# Altium schematic sheet lv_branch_24v.SchDoc(lv_branch_24v)
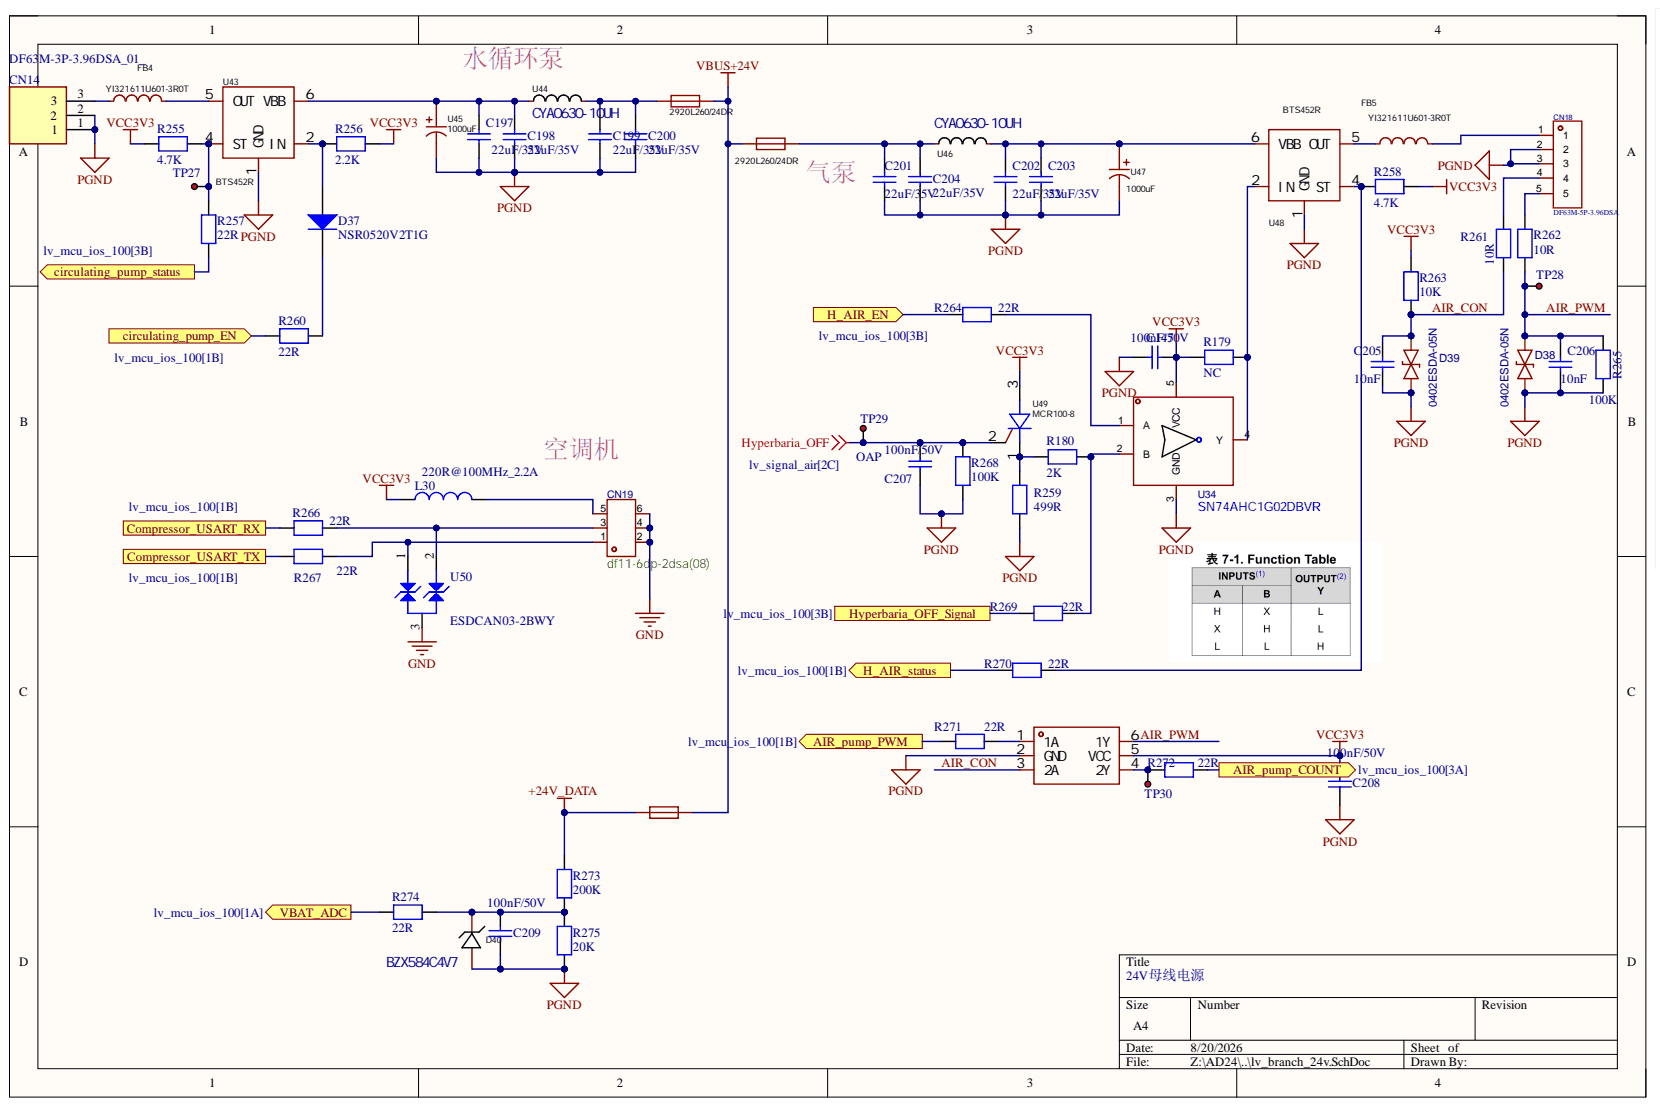
[diagram p0: lv_branch_24v.SchDoc]

## Parts
C147  100nF/50V  [C0402]
C197  22uF/35V  [C1206]
C198  22uF/35V  [C1206]
C199  22uF/35V  [C1206]
C200  22uF/35V  [C1206]
C201  22uF/35V  [C1206]
C202  22uF/35V  [C1206]
C203  22uF/35V  [C1206]
C204  22uF/35V  [C1206]
C205  value 10nF  [C0402]  (hidden comment: 10nF/50V)
C206  value 10nF  [C0402]  (hidden comment: 10nF/50V)
C207  100nF/50V  [C0402]
C208  100nF/50V  [C0402]
C209  100nF/50V  [C0402]
CN14  DF63M-3P-3.96DSA_01_  [DF63M3P396DSA01]
CN18  DF63M-5P-3.96DSA  [CONN-TH_5P-P3.96_HRS_DF63M-5P-3.96DSA]
CN19  df11-6dp-2dsa(08)  [CONN-TH_DF11-6DP-2DSA-01]
D37  NSR0520V2T1G  [SOD-523F_L1.2-W0.8-LS1.7-RD]
D38  0402ESDA-05N  [D0402-BI]
D39  0402ESDA-05N  [D0402-BI]
D40  BZX584C4V7  [SOD-523F_L1.2-W0.8-LS1.7-RD]
F3  2920L260/24DR  [F2920]
F4  2920L260/24DR  [F2920]
F5  2920L260/24DR  [F2920]
FB4  YI321611U601-3R0T  [L1206]
FB5  YI321611U601-3R0T  [L1206]
L30  220R@100MHz_2.2A  [L0603]
R179  NC  [R0603]
R180  2K  [R0402]
R255  4.7K  [R0402]
R256  2.2K  [R0603]
R257  22R  [R0402]
R258  4.7K  [R0402]
R259  499R  [R0603]
R260  22R  [R0402]
R261  10R  [R0603]
R262  10R  [R0603]
R263  10K  [R0603]
R264  22R  [R0402]
R265  100K  [R0603]
R266  22R  [R0402]
R267  22R  [R0402]
R268  100K  [R0402]
R269  22R  [R0402]
R270  22R  [R0402]
R271  22R  [R0402]
R272  22R  [R0402]
R273  200K  [R0603]
R274  22R  [R0402]
R275  20K  [R0603]
TP27  (no value)  [TP_SMD_1MM]
TP28  (no value)  [TP_SMD_1MM]
TP29  OAP  [TP_SMD_1MM]
TP30  (no value)  [TP_SMD_1MM]
U34  SN74AHC1G02DBVR  [SOT-23-5_L3.0-W1.7-P0.95-LS2.8-BR]
U43  BTS452R  [TO-252-5_L6.5-W6.2-P1.14-LS10.0-TL]
U44  CYA0630-10UH  [IND-SMD_L7.2-W6.6_GPSR07X0]
U45  1000uF  [CAP-TH_BD10.0-P5.00-D0.6-FD]
U46  CYA0630-10UH  [IND-SMD_L7.2-W6.6_GPSR07X0]
U47  1000uF  [CAP-TH_BD10.0-P5.00-D0.6-FD]
U48  BTS452R  [TO-252-5_L6.5-W6.2-P1.14-LS10.0-TL]
U49  MCR100-8  [SOT-23-3_L2.9-W1.3-P1.90-LS2.4-BR]
U50  ESDCAN03-2BWY  [DIO_SOT323]
U51  74LVC2G17GW,125  [SOT-363_L2.0-W1.3-P0.65-LS2.1-BR]  (value not drawn)

## Ports
AIR_pump_COUNT, AIR_pump_PWM, circulating_pump_EN, circulating_pump_status, Compressor_USART_RX, Compressor_USART_TX, H_AIR_EN, H_AIR_status, Hyperbaria_OFF_Signal, VBAT_ADC

## Nets
+24V_DATA: F5.2 R273.1
GND: CN19.2 CN19.4 CN19.6 U50.3
Hyperbaria_OFF: C207.2 R268.1 TP29.1 U49.2
PGND: C147.2 C197.1 C198.1 C199.1 C200.1 C201.1 C202.1 C203.1 C204.1 C205.1 C206.1 C207.1 C208.1 C209.1 CN14.1 CN14.2 CN18.2 CN18.3 D38.1 D39.1 D40.2 R259.2 R265.1 R268.2 R275.2 U34.3(GND) U43.1(GND) U45.2 U47.2 U48.1(GND) U51.2(GND)
VBUS+24V: F3.1 F4.1 F5.1
VCC3V3: C147.1 C208.2 L30.2 R179.1 R255.1 R256.1 R258.1 R263.2 U34.5(VCC) U49.3 U51.5(VCC)
AIR_CON: C205.2 D39.2 R261.2 R263.1 U51.3(2A)
AIR_pump_COUNT: R272.1 ; port AIR_pump_COUNT
AIR_pump_PWM: R271.1 ; port AIR_pump_PWM
AIR_PWM: C206.2 D38.2 R262.2 R265.2 TP28.1 U51.6(1Y)
circulating_pump_EN: R260.2 ; port circulating_pump_EN
circulating_pump_status: R257.2 ; port circulating_pump_status
Compressor_USART_RX: R266.1 ; port Compressor_USART_RX
Compressor_USART_TX: R267.1 ; port Compressor_USART_TX
H_AIR_EN: R264.1 ; port H_AIR_EN
H_AIR_status: R270.1 ; port H_AIR_status
Hyperbaria_OFF_Signal: R269.2 ; port Hyperbaria_OFF_Signal
VBAT_ADC: R274.1 ; port VBAT_ADC
NetC197_2: C197.2 C198.2 U43.6(VBB) U44.2 U45.1
NetC199_2: C199.2 C200.2 F3.2 U44.1
NetC201_2: C201.2 C204.2 F4.2 U46.1
NetC202_2: C202.2 C203.2 U46.2 U47.1 U48.6(VBB)
NetC209_2: C209.2 D40.1 R273.2 R274.2 R275.1
NetCN14_3: CN14.3 FB4.2
NetCN18_1: CN18.1 FB5.2
NetCN18_4: CN18.4 R261.1
NetCN18_5: CN18.5 R262.1
NetCN19_1: CN19.1 R267.2 U50.1
NetCN19_3: CN19.3 R266.2 U50.2
NetCN19_5: CN19.5 L30.1
NetD37_1: D37.1 R260.1
NetD37_2: D37.2 R256.2 U43.2(IN)
NetFB4_1: FB4.1 U43.5(OUT)
NetFB5_1: FB5.1 U48.5(OUT)
NetR179_2: R179.2 U34.4(Y) U48.2(IN)
NetR180_1: R180.1 R259.1 U49.1
NetR180_2: R180.2 R269.1 U34.2(B)
NetR255_2: R255.2 R257.1 TP27.1 U43.4(ST)
NetR258_2: R258.2 R270.2 U48.4(ST)
NetR264_2: R264.2 U34.1(A)
NetR271_2: R271.2 U51.1(1A)
NetR272_2: R272.2 TP30.1 U51.4(2Y)

## Library data of the parts
C147 C208 C209 = Capacitor: 50V, 10%, X7R; Internal_Part_Number: MCD-00003; Manufacturer: muRata; Manufacturer_Part_Number: GRM155R71H104KE14D; Value: 100nF; Component Kind: Standard; ComponentLink1Description: Datasheet; ComponentLink1URL: http://tunmcdsvn.tun.st.com/Component_Datasheet_Library/Trunk/Capacitors/Yageo_Capacitor_NP0_16V-to-50V; Library Name: HiPin_Library_AD.IntLib; Package: C0402
C197-C204 = Capacitor_2: 35V, X5R, 10%; Manufacturer: TDK; Manufacturer_Part_Number: C3216X5R1V226MTJ00E; Component Kind: Standard; ComponentLink1Description: Datasheet; ComponentLink1URL: http://tunmcdsvn.tun.st.com/Component_Datasheet_Library/Trunk/Capacitors/Yageo_Multilayer_capacitor_X5R_series_100pF_to_100uF; Library Name: HiPin_Library_AD.IntLib
C205 C206 = Capacitor: 50V, 10%, X7R; Internal_Part_Number: MCD-00003; Manufacturer: muRata; Manufacturer_Part_Number: GRM155R71H104KE14D; Component Kind: Standard; ComponentLink1Description: Datasheet; ComponentLink1URL: http://tunmcdsvn.tun.st.com/Component_Datasheet_Library/Trunk/Capacitors/Yageo_Capacitor_NP0_16V-to-50V; Library Name: HiPin_Library_AD.IntLib; Package: C0402
C207 = Capacitor: 50V, 5%, X7R; Manufacturer: muRata; Manufacturer_Part_Number: GRM155R71H104KE14D; Component Kind: Standard; ComponentLink1Description: Datasheet; ComponentLink1URL: http://tunmcdsvn.tun.st.com/Component_Datasheet_Library/Trunk/Capacitors/Yageo_Capacitor_NP0_16V-to-50V; Library Name: HiPin_Library_AD.IntLib
CN14 = DF63M-3P-3.96DSA_01_: Connector Header Through Hole 3 position 0.156" (3.96mm); Manufacturer_Name: Hirose; Manufacturer_Part_Number: DF63M-3P-3.96DSA(01); Geometry.Height: 10.3mm; Component Kind: Standard; Datasheet Link: https://www.hirose.com/en/product/document?clcode=CL0680-0568-0-01&productname=DF63M-3P-3.96DSA(01)&series=DF63&documenttype=2DDrawing&lang=en&documentid=0001287261; Mouser Part Number: 798-DF63M3P396DSA01; Mouser Price/Stock: https://www.mouser.co.uk/ProductDetail/Hirose-Connector/DF63M-3P-3.96DSA01?qs=T3oQrply3y%2FNBBEqsbs72w%3D%3D; Library Name: HIPIN_Libraries_2022.SchLib
CN18 = DF63M-5P-3.96DSA: 5PIN，3.96mm插座; Component Kind: Standard; Library Name: HiPin_Library_AD.IntLib
CN19 = df11-6dp-2dsa: 插针结构:2x3P;间距:2mm;安装方式:直插;参考系列:-;总PIN数:6P;排数:2;每排PIN数:3;行距:2mm;额定电流:-;工作温度范围:-;; Manufacturer: HRS(广濑); AddInBom: yes; ModelId: f6522031bd444346802bb24726d5ca22; ModelTransform: 314.96,196.85,0,0,0,0,0,0,-118.11; Number of PINs Per Row: 3; Pins Structure: 2x3P; Pitch: 2mm; Component Kind: Standard; ConvertToPcb: yes; Current Rating (Max): -; Datasheet: https://atta.szlcsc.com/upload/public/pdf/source/20200402/C506668_15971A609117B16797466F71D3345786.pdf; FootprintName: CONN-TH_DF11-6DP-2DSA-01; JLCPCB Part Class: Extended Part; LCSC Part Name: 2x3P 间距:2mm 直插; Library Name: HiPin_Library_AD.IntLib; ManufacturerPart: DF11-6DP-2DSA(08); ModelName: CONN-TH_DF11-6DP-2DSA-01; Mounting Style: 直插; Number of Pins: 6P; Number of Rows: 2; Operating Temperature Range: -; Reference Series: -; Row Spacing: 2mm; Supplier: LCSC; Supplier Footprint: 插件,P=2mm; SupplierPart: C506668; Symbol: DF11-6DP-2DSA(08)
D37 = 1N4148: Component Kind: Standard; Library Name: HiPin_Library_AD.IntLib
D38 D39 = 0402ESDA-05N: 双向ESD，反向截止电压5V; BOM_Manufacturer: Bourne Semicon (Shenzhen); Contributor: LCSC; BOM_JLCPCB Part Class: Extended Part; BOM_Manufacturer Part: 0402ESDA-05N; BOM_Supplier: LCSC; BOM_Supplier Part: C316049; Component Kind: Standard; Library Name: HiPin_Library_AD.IntLib; spicePre: D; spiceSymbolName: 0402ESDA-05N
D40 = LM5Z3V6T1G: 4.8~5.4V, 200mW; Power Dissipation: 500mW; Component Kind: Standard; Library Name: HiPin_Library_AD.IntLib; Manufacturer Part: BZX584C4V7; Supplier Footprint: SOD-123; Tolerance: -
F3-F5 = 2920L260/24DR: 自恢复保险丝2.6A，5A@10s; Manufacturer: Littelfuse(美国力特); Add into BOM: yes; Current Rating (Max): 40A; Hold Current: 2.6A; Maximum Time to Trip: 10s; Operating Voltage (Max): 24V; Resistance - Initial (Ri) (Min): 25mΩ; Resistance - Post Trip (R1) (Max): 75mΩ; Trip Current: 5A; Component Kind: Standard; Convert to PCB: yes; Datasheet: https://atta.szlcsc.com/upload/public/pdf/source/20181031/C315905_4DA816BF3BC9EA6CE3926B09DB032E96.pdf; Device: 2920L260/24DR; JLCPCB Part Class: Extended Part; LCSC Part Name: 24V 2.6A 2920自恢复; Library Name: HiPin_Library_AD.IntLib; Manufacturer Part: 2920L260/24DR; Operating Temperature: -40℃~+85℃; Power Dissipation: -; Supplier: LCSC; Supplier Footprint: 2920; Supplier Part: C315904; Symbol: 2920L260/24DR
FB4 FB5 = BLM31PG601SN1L: 600Ω@100MHz磁珠，3A，60mΩ，25%; Component Kind: Standard; Library Name: HiPin_Library_AD.IntLib
L30 = MCD-00047: SMD Chip ferrite bead, 220R@100MHz +/-25%,2.2A, 50mohm; Manufacturer: Sunlord; Manufacturer_Part_Number: UPZ1608U221-2R2TF; Database Table Name: MCD_PARTS$; Component Kind: Standard; ComponentLink1Description: Datasheet; ComponentLink1URL: http://tunmcdsvn.tun.st.com/Component_Datasheet_Library/Trunk/Inductors/TaiTech_Bead_FCM1608_series; Internal_Part_Number: MCD-00047; Library Name: MCD_PARTS_database.DbLib
R179 R180 R255-R262 R264-R275 = RES: 1%; Value: 10K; Component Kind: Standard; Library Name: HiPin_Library_AD.IntLib
R263 = RES: 1%; Component Kind: Standard; Library Name: HiPin_Library_AD.IntLib; Value: 10K
TP27-TP30 = PT: 测试点帽子; Component Kind: Standard; Library Name: HiPin_Library_AD.IntLib
U34 = SN74AHC1G02DBVR: 或非门; Component Kind: Standard; Library Name: HiPin_Library_AD.IntLib; Symbol: SN74AHC1G02DBVR
U43 U48 = BTS452R_C126924: 高位电子开关; Manufacturer: Infineon(英飞凌); Add into BOM: yes; Component Kind: Standard; Convert to PCB: yes; Datasheet: https://atta.szlcsc.com/upload/public/pdf/source/20190419/C386345_DBEE7F1C619255E05785D0D1BACFA6FB.pdf; Device: BTS452R_C126924; JLCPCB Part Class: Extended Part; LCSC Part Name: BTS452R; Library Name: HiPin_Library_AD.IntLib; Manufacturer Part: BTS452R; Supplier: LCSC; Supplier Footprint: TO-252-4; Supplier Part: C126924; Symbol: BTS452R
U44 U46 = CYA0630-10UH: 10uH,4A,71mΩ，20%; Manufacturer: SHOU HAN(首韩); Add into BOM: yes; DC Resistance (DCR): 71mΩ; Tolerance: ±20%; Component Kind: Standard; Convert to PCB: yes; Datasheet: https://atta.szlcsc.com/upload/public/pdf/source/20221010/B55E8796F05F85A38044F3826C14EFDD.pdf; Device: CYA0630-10UH; JLCPCB Part Class: Extended Part; LCSC Part Name: 10uH ±20%; Library Name: HiPin_Library_AD.IntLib; Manufacturer Part: CYA0630-10UH; Name: 10uH; PCB3D: IND-SMD_L7.2-W6.6-H3.0_GPSR07X0; Rated Current: 4A; Supplier: LCSC; Supplier Footprint: SMD,6.6x7.2mm; Supplier Part: C5189958; Symbol: CYA0630-10UH; Value: 10uH
U45 U47 = HG1V108M1016PC: 35V, 20%; Manufacturer: HONOR(荣誉); Add into BOM: yes; Equivalent Series Resistance(ESR): 28mΩ; L: 16mm; Lifetime @ Temp: 2000hrs@105℃; Ripple Current: 4.2A; φD: 10mm; Component Kind: Standard; Convert to PCB: yes; Datasheet: https://atta.szlcsc.com/upload/public/pdf/source/20230323/E6B46A248FBB182638B68380B3289E6D.pdf; Device: HG1V108M1016PC; JLCPCB Part Class: Extended Part; LCSC Part Name: 1000uF ±20% 35V; Lead Spacing: 5mm; Library Name: HiPin_Library_AD.IntLib; Manufacturer Part: HG1V108M1016PC; Operating Temperature: -55℃~+105℃; Rated Voltage: 35V; Supplier: LCSC; Supplier Footprint: 插件,D10xL16mm; Supplier Part: C5438864; Symbol: HG1V108M1016PC; Tolerance: ±20%; Value: 1000uF
U49 = MCR100-8_C5184437: 可控硅; Manufacturer: HXY MOSFET(华轩阳电子); Add into BOM: yes; Average Gate Power Dissipation (PG(AV)): 100mW; Gate Trigger   Voltage (Vgt): 800mV; Gate Trigger Current(Igt): 60uA; Holding Current (Ih): 5mA; Peak non-repetitive surge current (Itsm@f): 8A; Peak Repetitive Off?State Voltage (Vdrm): 600V; RMS On-State Current(It (rms)): 800mA; SCR Type: 单向可控硅; Voltage - On State (Vtm): 1.7V; Component Kind: Standard; Convert to PCB: yes; Datasheet: https://atta.szlcsc.com/upload/public/pdf/source/20240902/7358CD860A43FFBE3B53FCDEB35A6F8C.pdf; Device: MCR100-8_C5184437; JLCPCB Part Class: Extended Part; LCSC Part Name: 单向 Vgt: 800mV IT:0.8A Vdrm: 600V; Library Name: HiPin_Library_AD.IntLib; Manufacturer Part: MCR100-8; Operating Temperature: -; PCB3D: SOT-23-3_L2.9-W1.3-P1.90-LS2.4-BR-CW; Supplier: LCSC; Supplier Footprint: SOT-23; Supplier Part: C5184437; Symbol: MCR100-8_C5184437
U50 = MCD-01228: 双线TVS,反向截止电压24V; Manufacturer: STMICROELECTRONICS; Database Table Name: MCD_PARTS$; Component Kind: Standard; ComponentLink1Description: Datasheet; ComponentLink1URL: http://tunmcdsvn.tun.st.com/Component_Datasheet_Library/Trunk/Diodes/STM_ESD_ESDCAN02-2BWY; Library Name: MCD_PARTS_database.DbLib; Manufacturer_Part_Number: ESDCAN03-2BWY
U51 = 74LVC2G17GW,125: 2路斯密特触发器; Manufacturer: Nexperia(安世); Add into BOM: yes; Current - Output High(IOH): 32mA; Input type: 施密特触发器; Logic Family: 74LVC; Number of Bits per Element: 1; Number of Elements: 2; output type: 推挽; Voltage - Supply: 1.65V~5.5V; Component Kind: Standard; Convert to PCB: yes; Current - Output Low(IOL): 32mA; Datasheet: https://atta.szlcsc.com/upload/public/pdf/source/20240724/F8BC06F6786231A20F016105A2EDA3EF.pdf; Device: 74LVC2G17GW,125; JLCPCB Part Class: Extended Part; LCSC Part Name: 74LVC2G17GW,125; Library Name: HiPin_Library_AD.IntLib; Manufacturer Part: 74LVC2G17GW,125; Operating Temperature: -40℃~+125℃; PCB3D: SOT-363-6P_L2.0-W1.3-H1.1-LS2.0-P0.65; Supplier: LCSC; Supplier Footprint: SOT-363; Supplier Part: C80500; Symbol: 74LVC2G17GW,125
